# Altium schematic sheet GD32F450_Main_Board(Camera)_V1.0.SchDoc
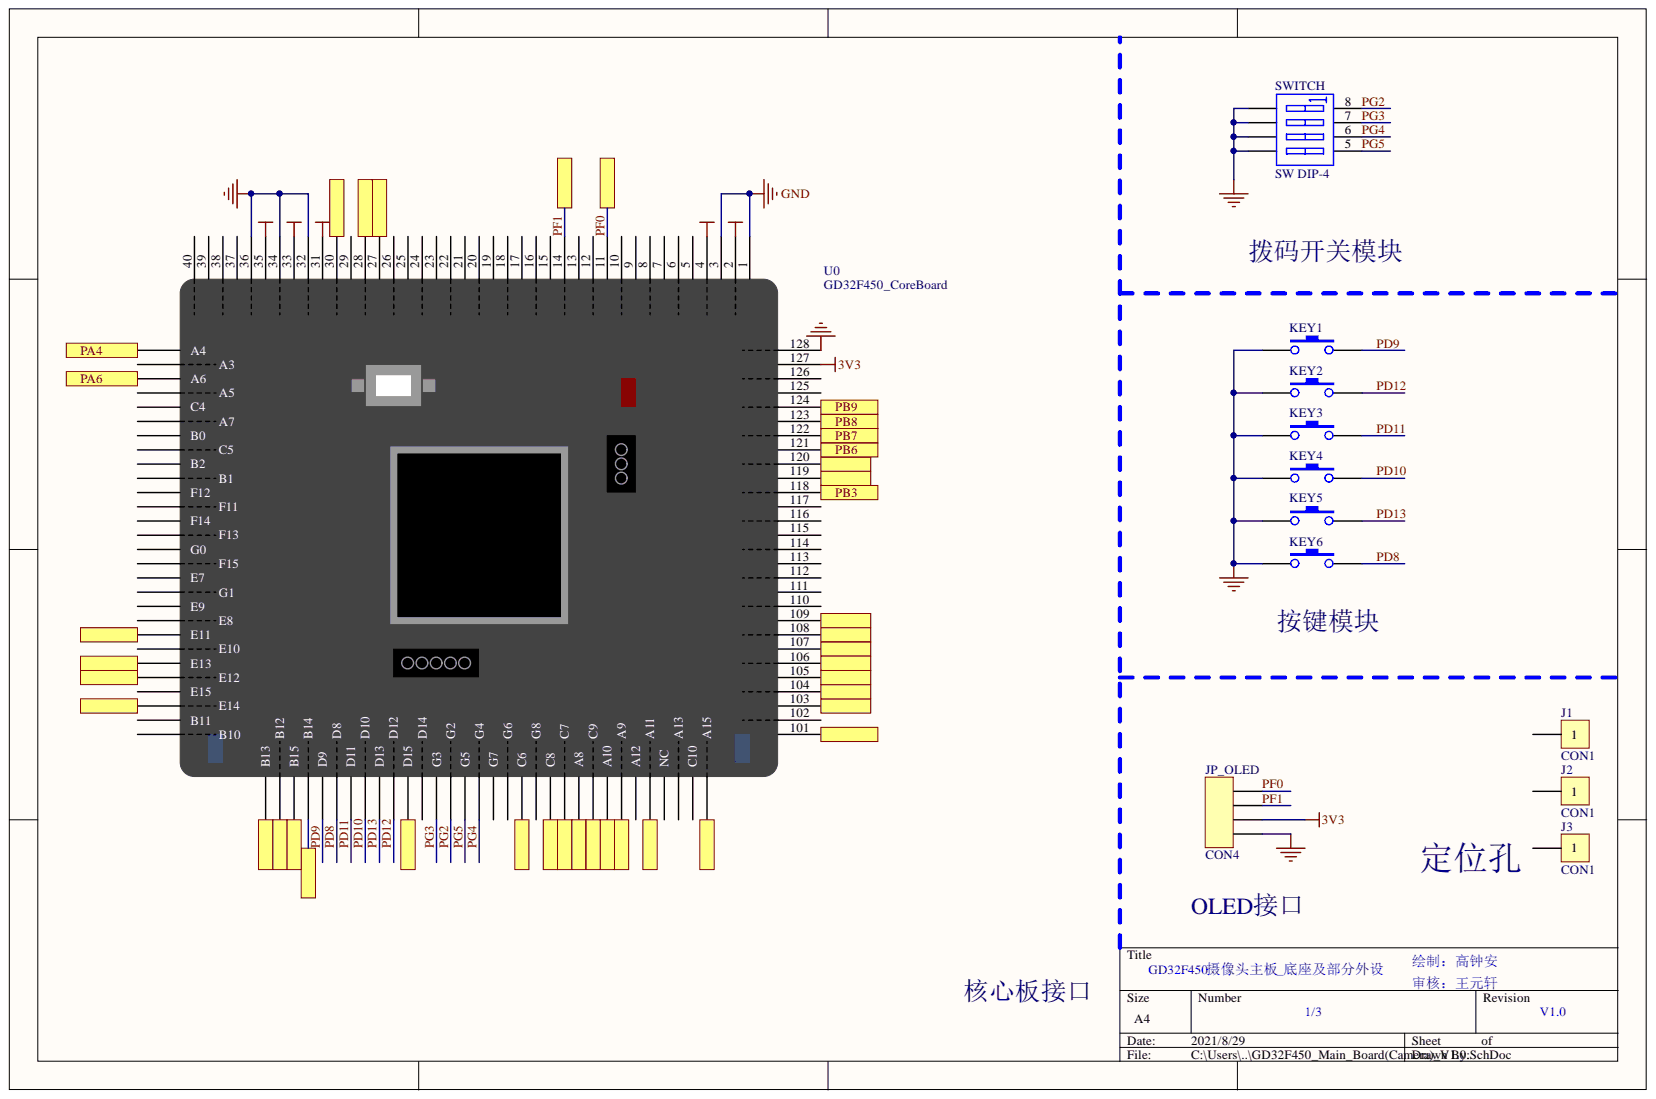
[diagram p0: GD32F450_Main_Board(Camera)_V1.0.SchDoc]

## Parts
J1  CON1  [HOLE-3.5]
J2  CON1  [HOLE-3.5]
J3  CON1  [HOLE-3.5]
JP_OLED  CON4  [OLED]
KEY1  SW-PB  [KEY2-6X3]  (value not drawn)
KEY2  SW-PB  [KEY2-6X3]  (value not drawn)
KEY3  SW-PB  [KEY2-6X3]  (value not drawn)
KEY4  SW-PB  [KEY2-6X3]  (value not drawn)
KEY5  SW-PB  [KEY2-6X3]  (value not drawn)
KEY6  SW-PB  [KEY2-6X3]  (value not drawn)
SWITCH  SW DIP-4  [CSW DIP-4P]
U0  GD32F450_CoreBoard  [GD32F450_CoreBoard]

## Ports
PA0, PA1, PA2, PA4, PA6, PA8, PA9, PA10, PA11, PA15, PB3, PB4, PB5, PB6, PB7, PB8, PB9, PB12, PB13, PB14, PB15, PC6, PC7, PC8, PC9, PC11, PD0, PD1, PD2, PD3, PD4, PD5, PD6, PD15, PE11, PE12, PE13, PE14, PF0, PF1

## Nets
3V3: JP_OLED.2 U0.2 U0.4 U0.127
GND: JP_OLED.1 KEY1.1 KEY2.1 KEY3.1 KEY4.1 KEY5.1 KEY6.1 SWITCH.1 SWITCH.2 SWITCH.3 SWITCH.4 U0.1 U0.3 U0.32 U0.34 U0.36 U0.128
VCC: U0.31 U0.33 U0.35
PA0: U0.28 ; port PA0
PA1: U0.27 ; port PA1
PA2: U0.30 ; port PA2
PA4: U0.41(A4) ; port PA4
PA6: U0.43(A6) ; port PA6
PA8: U0.91(A8) ; port PA8
PA9: U0.94 ; port PA9
PA10: U0.93(A10) ; port PA10
PA11: U0.96 ; port PA11
PA15: U0.100 ; port PA15
PB3: U0.118 ; port PB3
PB4: U0.119 ; port PB4
PB5: U0.120 ; port PB5
PB6: U0.121 ; port PB6
PB7: U0.122 ; port PB7
PB8: U0.123 ; port PB8
PB9: U0.124 ; port PB9
PB12: U0.70 ; port PB12
PB13: U0.69(B13) ; port PB13
PB14: U0.72 ; port PB14
PB15: U0.71(B15) ; port PB15
PC6: U0.87(C6) ; port PC6
PC7: U0.90 ; port PC7
PC8: U0.89(C8) ; port PC8
PC9: U0.92 ; port PC9
PC11: U0.101 ; port PC11
PD0: U0.103 ; port PD0
PD1: U0.104 ; port PD1
PD2: U0.105 ; port PD2
PD3: U0.106 ; port PD3
PD4: U0.107 ; port PD4
PD5: U0.108 ; port PD5
PD6: U0.109 ; port PD6
PD8: KEY6.2 U0.74
PD9: KEY1.2 U0.73(D9)
PD10: KEY4.2 U0.76
PD11: KEY3.2 U0.75(D11)
PD12: KEY2.2 U0.78
PD13: KEY5.2 U0.77(D13)
PD15: U0.79(D15) ; port PD15
PE11: U0.61(E11) ; port PE11
PE12: U0.64 ; port PE12
PE13: U0.63(E13) ; port PE13
PE14: U0.66 ; port PE14
PF0: JP_OLED.4 U0.11 ; port PF0
PF1: JP_OLED.3 U0.14 ; port PF1
PG2: SWITCH.8 U0.82
PG3: SWITCH.7 U0.81(G3)
PG4: SWITCH.6 U0.84
PG5: SWITCH.5 U0.83(G5)

Not connected: J1 1; J2 1; J3 1; U0 5 6 7 8 9 10 12 13 15 16 17 18 19 20 21 22 23 24 25 26 29 37 38 39 40 42 44 45(C4) 46 47(B0) 48 49(B2) 50 51(F12) 52 53(F14) 54 55(G0) 56 57(E7) 58 59(E9) 60 62 65(E15) 67(B11) 68 80 85(G7) 86 88 95(A12) 97(NC) 98 99(C10) 102 110 111 112 113 114 115 116 117 125 126

## Library data of the parts
J1-J3 = CON1: Connector; Component Kind: Standard; Library Name: Protel99Lib_SCH.SCHLIB
JP_OLED = CON4: Connector; Component Kind: Standard; Library Name: Protel99Lib_SCH.SCHLIB
SWITCH = SW DIP-4: DIP Switch; Component Kind: Standard; Library Name: Protel99Lib_SCH.SCHLIB
KEY1-KEY6 = SW-PB: Component Kind: Standard; Library Name: Protel99Lib_SCH.SCHLIB
U0 = GD32F450_CoreBoard: Component Kind: Standard; Library Name: GD32F450核心板整体封装.SchLib
